# Altium schematic sheet Analog.SchDoc
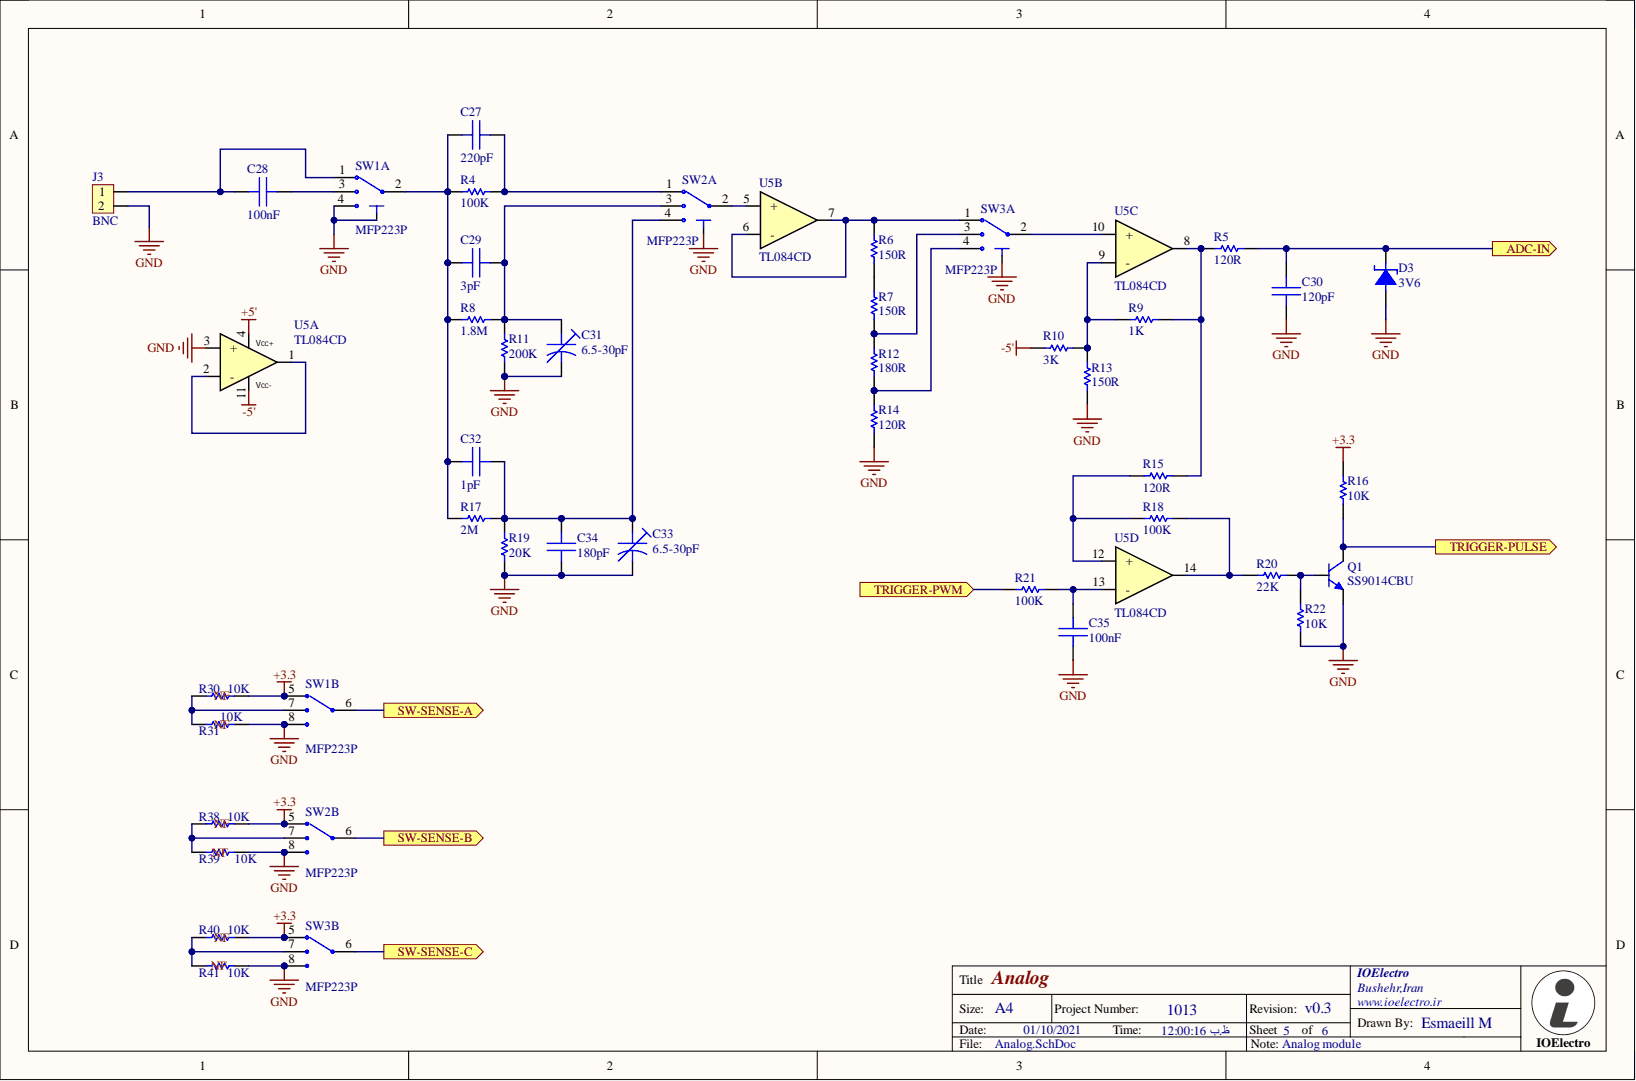
[diagram p0: Analog.SchDoc]

## Parts
C27  220pF  [C-BP-Ceramic-DIP]
C28  100nF  [C-BP-Ceramic-DIP]
C29  3pF  [C-BP-Ceramic-DIP]
C30  120pF  [C-BP-Ceramic-DIP]
C31  6.5-30pF  [C-TR-DIP_5mm]
C32  1pF  [C-BP-Ceramic-DIP]
C33  6.5-30pF  [C-TR-DIP_5mm]
C34  180pF  [C-BP-Ceramic-DIP]
C35  100nF  [C-BP-Ceramic-DIP]
D3  3V6  [SOD80]
J3  BNC  [PH-1X2-N3D]
Q1  SS9014CBU  [TO-92]
R4  100K  [R-1/4W-DIP-Blue]
R5  120R  [R-1/4W-DIP-Blue]
R6  150R  [R-1/4W-DIP-Blue]
R7  150R  [R-1/4W-DIP-Blue]
R8  1.8M  [R-1/4W-DIP-Blue]
R9  1K  [R-1/4W-DIP-Blue]
R10  3K  [R-1/4W-DIP-Blue]
R11  200K  [R-1/4W-DIP-Blue]
R12  180R  [R-1/4W-DIP-Blue]
R13  150R  [R-1/4W-DIP-Blue]
R14  120R  [R-1/4W-DIP-Blue]
R15  120R  [R-1/4W-DIP-Blue]
R16  10K  [R-0805]
R17  2M  [R-1/4W-DIP-Blue]
R18  100K  [R-1/4W-DIP-Blue]
R19  20K  [R-1/4W-DIP-Blue]
R20  22K  [R-0805]
R21  100K  [R-1/4W-DIP-Blue]
R22  10K  [R-0805]
R30  10K  [R-0805]
R31  10K  [R-0805]
R38  10K  [R-0805]
R39  10K  [R-0805]
R40  10K  [R-0805]
R41  10K  [R-0805]
SW1  MFP223P  [MFP223P]  (parts A,B)
SW2  MFP223P  [MFP223P]  (parts A,B)
SW3  MFP223P  [MFP223P]  (parts A,B)
U5  TL084CD  [SO-14]  (parts A,B,C,D)

## Ports
ADC-IN, SW-SENSE-A, SW-SENSE-B, SW-SENSE-C, TRIGGER-PULSE, TRIGGER-PWM

## Nets
+3.3: R16.2 R30.1 R38.1 R40.1 SW1.5 SW2.5 SW3.5
+5': U5.4
-5': R10.1 U5.11
GND: C30.2 C31.K C33.K C34.2 C35.2 D3.A J3.2 Q1.1 R11.1 R13.2 R14.1 R19.1 R22.1 R31.1 R39.1 R41.1 SW1.0 SW1.4 SW1.8 SW2.0 SW2.8 SW3.0 SW3.8 U5.3(+)
ADC-IN: C30.1 D3.K R5.1 ; port ADC-IN
SW-SENSE-A: SW1.6 ; port SW-SENSE-A
SW-SENSE-B: SW2.6 ; port SW-SENSE-B
SW-SENSE-C: SW3.6 ; port SW-SENSE-C
TRIGGER-PULSE: Q1.3 R16.1 ; port TRIGGER-PULSE
TRIGGER-PWM: R21.2 ; port TRIGGER-PWM
NetC27_1: C27.1 R4.2 SW2.1
NetC27_2: C27.2 C29.2 C32.2 R4.1 R8.1 R17.1 SW1.2
NetC28_1: C28.1 SW1.3
NetC28_2: C28.2 J3.1 SW1.1
NetC29_1: C29.1 C31.A R8.2 R11.2 SW2.3
NetC32_1: C32.1 C33.A C34.1 R17.2 R19.2 SW2.4
NetC35_1: C35.1 R21.1 U5.13(-)
NetQ1_2: Q1.2 R20.1 R22.2
NetR5_2: R5.2 R9.1 R15.1 U5.8
NetR6_1: R6.1 R7.2
NetR6_2: R6.2 SW3.1 U5.6(-) U5.7
NetR7_1: R7.1 R12.2 SW3.3
NetR9_2: R9.2 R10.2 R13.1 U5.9(-)
NetR12_1: R12.1 R14.2 SW3.4
NetR15_2: R15.2 R18.2 U5.12(+)
NetR18_1: R18.1 R20.2 U5.14
NetR30_2: R30.2 R31.2 SW1.7
NetR38_2: R38.2 R39.2 SW2.7
NetR40_2: R40.2 R41.2 SW3.7
NetSW2_2: SW2.2 U5.5(+)
NetSW3_2: SW3.2 U5.10(+)
NetU5_1: U5.1 U5.2(-)

## Library data of the parts
C27-C30 C32 C34 C35 = CAP: Ceramic Disk Capacitor 50V; Component Kind: Standard; Library Name: IOElectro.IntLib
C31 C33 = GKG30015: Trimmer / Variable Capacitors 6.5-30pF 100V 85 DEG; Manufacturer: Sprague Goodman; Q Factor: 300; Category: Trimmer / Variable Capacitors; Component Kind: Standard; ComponentLink1Description: http://www.mouser.com/catalog/specsheets/659305J.pdf?src-supplier=Mouser; ComponentLink1URL: http://www.mouser.com/catalog/specsheets/659305J.pdf?src-supplier=Mouser; ComponentLink2Description: https://media.digikey.com/pdf/Data%20Sheets/Sprague-Goodman%20PDFs/Ceramic%20Dielectric%20Trimmer%20Caps.pdf?src-supplier=Digi-Key; ComponentLink2URL: https://media.digikey.com/pdf/Data%20Sheets/Sprague-Goodman%20PDFs/Ceramic%20Dielectric%20Trimmer%20Caps.pdf?src-supplier=Digi-Key; ComponentLink3Description: http://www.spraguegoodman.com/pdfs/305.pdf?src-supplier=Digi-Key; ComponentLink3URL: http://www.spraguegoodman.com/pdfs/305.pdf?src-supplier=Digi-Key; Features: General Purpose; Height: 0.2inch; Height - Seated (Max): 0.197inch; Lead Free: Lead Free; Lead Pitch: 0.24inch; Length: 6mm; Library Name: IOElectro.IntLib; Manufacturer Part Number: GKG30015; Max Operating Temperature: 85°C; Min Operating Temperature: -25°C; Mount: Through Hole; Package Quantity: 500; Packaging: Bulk; Resistor Type: Ceramic; RoHS: Yes; RoHS Compliant: Yes; Series: SURFTRIM® GKG; Supplier 1: Digi-Key; Supplier Part Number 1: SG30015-ND; Termination: Snap in; Voltage Rating: 100V; Voltage Rating (DC): 100V
D3 = DIODE-Z: SMD MiniMELF; Component Kind: Standard; Library Name: IOElectro.IntLib
J3 = PH-1X2: PIN HEADER 1x2 2.56mm; Component Kind: Standard; Library Name: Schlib.SchLib
Q1 = SS9014CBU: Bipolar (BJT) Single Transistor, NPN, 45 V, 270 MHz, 450 mW, 100 mA, 200; Manufacturer: ON Semiconductor; Collector Base Voltage (VCBO): 50V; Collector Emitter Breakdown Voltage: 45V; Collector Emitter Saturation Voltage: 140mV; Collector-emitter Voltage-Max: 300mV; Current Rating: 100mA; Emitter Base Voltage (VEBO): 5V; Frequency: 270MHz; hFE Min: 60; Max Power Dissipation: 450mW; Number of Elements: 1; Case/Package: TO-92; Category: BJTs; Collector Emitter Voltage (VCEO): 45V; Component Kind: Standard; ComponentLink1Description: https://datasheet.ciiva.com/11207/ss9014-11207537.pdf?src-supplier=Avnet; ComponentLink1URL: https://datasheet.ciiva.com/11207/ss9014-11207537.pdf?src-supplier=Avnet; ComponentLink2Description: https://datasheet.ciiva.com/46415/1863528-46415612.pdf?src-supplier=Element14; ComponentLink2URL: https://datasheet.ciiva.com/46415/1863528-46415612.pdf?src-supplier=Element14; ComponentLink3Description: https://datasheet.ciiva.com/978/ss9014-978974.pdf?src-supplier=Digi-Key; ComponentLink3URL: https://datasheet.ciiva.com/978/ss9014-978974.pdf?src-supplier=Digi-Key; ComponentLink4Description: http://datasheet.octopart.com/SS9014CBU-Fairchild-datasheet-4532.pdf?src-supplier=Newark; ComponentLink4URL: http://datasheet.octopart.com/SS9014CBU-Fairchild-datasheet-4532.pdf?src-supplier=Newark; ComponentLink5Description: http://datasheet.octopart.com/SS9014CBU-ON-Semiconductor-datasheet-85608206.pdf?src-supplier=ON+Semiconductor; ComponentLink5URL: http://datasheet.octopart.com/SS9014CBU-ON-Semiconductor-datasheet-85608206.pdf?src-supplier=ON+Semiconductor; ComponentLink6Description: http://datasheet.octopart.com/SS9014CBU-ON-Semiconductor-datasheet-86698737.pdf?src-supplier=ON+Semiconductor; ComponentLink6URL: http://datasheet.octopart.com/SS9014CBU-ON-Semiconductor-datasheet-86698737.pdf?src-supplier=ON+Semiconductor; ComponentLink7Description: http://datasheet.octopart.com/SS9014CBU-ON-Semiconductor-datasheet-102168068.pdf?src-supplier=ON+Semiconductor; ComponentLink7URL: http://datasheet.octopart.com/SS9014CBU-ON-Semiconductor-datasheet-102168068.pdf?src-supplier=ON+Semiconductor; ComponentLink8Description: http://datasheet.octopart.com/SS9014CBU-ON-Semiconductor-datasheet-86693094.pdf?src-supplier=Element14; ComponentLink8URL: http://datasheet.octopart.com/SS9014CBU-ON-Semiconductor-datasheet-86693094.pdf?src-supplier=Element14; ComponentLink9Description: http://sigma.octopart.com/8329026/technical_drawing/Fairchild-Semiconductor-SS9014CBU.pdf?src-supplier=Fairchild+Semiconductor; ComponentLink9URL: http://sigma.octopart.com/8329026/technical_drawing/Fairchild-Semiconductor-SS9014CBU.pdf?src-supplier=Fairchild+Semiconductor; ComponentLink10Description: http://datasheet.octopart.com/SS9014CBU-Fairchild-Semiconductor-datasheet-67476720.pdf?src-supplier=Newark; ComponentLink10URL: http://datasheet.octopart.com/SS9014CBU-Fairchild-Semiconductor-datasheet-67476720.pdf?src-supplier=Newark; ComponentLink11Description: http://datasheet.octopart.com/SS9014CBU-Fairchild-Semiconductor-datasheet-68342036.pdf?src-supplier=Farnell; ComponentLink11URL: http://datasheet.octopart.com/SS9014CBU-Fairchild-Semiconductor-datasheet-68342036.pdf?src-supplier=Farnell; ComponentLink12Description: http://datasheet.octopart.com/SS9014CBU-Fairchild-Semiconductor-datasheet-68481733.pdf?src-supplier=Newark; ComponentLink12URL: http://datasheet.octopart.com/SS9014CBU-Fairchild-Semiconductor-datasheet-68481733.pdf?src-supplier=Newark; ComponentLink13Description: http://datasheet.octopart.com/SS9014CBU-Fairchild-Semiconductor-datasheet-68661175.pdf?src-supplier=Farnell; ComponentLink13URL: http://datasheet.octopart.com/SS9014CBU-Fairchild-Semiconductor-datasheet-68661175.pdf?src-supplier=Farnell; ComponentLink14Description: http://datasheet.octopart.com/SS9014CBU-Fairchild-Semiconductor-datasheet-78857804.pdf?src-supplier=Farnell; ComponentLink14URL: http://datasheet.octopart.com/SS9014CBU-Fairchild-Semiconductor-datasheet-78857804.pdf?src-supplier=Farnell; ComponentLink15Description: http://datasheet.octopart.com/SS9014CBU-Fairchild-Semiconductor-datasheet-78861914.pdf?src-supplier=Newark; ComponentLink15URL: http://datasheet.octopart.com/SS9014CBU-Fairchild-Semiconductor-datasheet-78861914.pdf?src-supplier=Newark; Element Configuration: Single; Gain Bandwidth Product: 270MHz; Height: 4.58mm; Lead Free: Lead Free; Length: 4.58mm; Library Name: IOElectro.IntLib; Manufacturer Part Number: SS9014CBU; Max Collector Current: 100mA; Max Frequency: 270MHz; Max Operating Temperature: 150°C; Min Operating Temperature: -55°C; Mount: Through Hole; Number of Pins: 3; Package Quantity: 1000; Packaging: Bulk; Polarity: NPN; Power Dissipation: 450mW; Radiation Hardening: No; REACH SVHC: No SVHC; RoHS Compliant: Yes; Series: -; Supplier 1: Digi-Key; Supplier Part Number 1: SS9014CBU-ND; Transition Frequency: 270MHz; Voltage Rating (DC): 45V; Weight: 0.179g; Width: 3.86mm
R4-R15 R17-R19 R21 = RES: Resistor DIP 1/4W 1%; Component Kind: Standard; Library Name: IOElectro.IntLib
R16 R20 R22 R30 R31 R38-R41 = RES: 0805; Component Kind: Standard; Library Name: IOElectro.IntLib
SW1-SW3 = MFP223P: Switch Slide ON ON ON Extended Top Slide 0.35A 30VDC 10000Cycle PC Pins Bracket Mount/Through Hole; Manufacturer: Knitter-Switch; Actuator Material: Polyoxymethylene; Max Current Rating: 200mA; Max Voltage Rating (DC): 30V; Mechanical Life: 10000Cycles; Category: Uncategorized; Component Kind: Standard; ComponentLink1Description: https://datasheet.ciiva.com/26847/getdatasheetpartid-740636-26847254.pdf?src-supplier=Verical; ComponentLink1URL: https://datasheet.ciiva.com/26847/getdatasheetpartid-740636-26847254.pdf?src-supplier=Verical; Contact Material: Copper Alloy; Contact Plating: Nickel,Silver; Depth: 6.5mm; Housing Material: Resin; Lead Free: Lead Free; Length: 16mm; Manufacturer Part Number: MFP223P; Max Operating Temperature: 85°C; Min Operating Temperature: -20°C; Mount: Through Hole,Brackets; Radiation Hardening: No; RoHS: Yes; RoHS Compliant: Yes; Supplier 1: Arrow Europe; Supplier Part Number 1: MFP223P; Termination: PC Pins
  SW1: Library Name: Schlib.SchLib
  SW2 SW3: Library Name: IOElectro.IntLib
U5 = TL084CD: IC OPAMP JFET 4MHZ 14SO; Manufacturer: STMicroelectronics; Amplifier Type: GENERAL PURPOSE; Common Mode Rejection Ratio: 70dB; Dual Supply Voltage: 15V; Input Bias Current: 200pA; Input Offset Voltage (Vos): 10mV; Max Dual Supply Voltage: 18V; Max Supply Voltage: 36V; Min Dual Supply Voltage: 3V; Min Input Voltage: -12V; Nominal Supply Current: 2.5mA; Number of Amplifiers: 4; Operating Supply Current: 1.4mA; Output Current: 40mA; Power Dissipation: 680mW; Rise Time: 50ns; Slew Rate: 16V/µs; Voltage Gain: 106.02dB; Bandwidth: 4MHz; Case/Package: SOIC; Category: Amplifiers - Audio; Component Kind: Standard; ComponentLink1Description: https://datasheet.ciiva.com/15129/getdatasheetpartid-40720-15129705.pdf?src-supplier=Verical; ComponentLink1URL: https://datasheet.ciiva.com/15129/getdatasheetpartid-40720-15129705.pdf?src-supplier=Verical; ComponentLink2Description: https://datasheet.ciiva.com/29306/155629-da-01-en-op-verst-tl084cd-stm-29306876.pdf?src-supplier=Conrad; ComponentLink2URL: https://datasheet.ciiva.com/29306/155629-da-01-en-op-verst-tl084cd-stm-29306876.pdf?src-supplier=Conrad; ComponentLink3Description: https://datasheet.ciiva.com/1796/cd00000493-1796695.pdf?src-supplier=Digi-Key; ComponentLink3URL: https://datasheet.ciiva.com/1796/cd00000493-1796695.pdf?src-supplier=Digi-Key; ComponentLink4Description: https://datasheet.ciiva.com/16385/cd00000493-16385768.pdf?src-supplier=Future+Electronics; ComponentLink4URL: https://datasheet.ciiva.com/16385/cd00000493-16385768.pdf?src-supplier=Future+Electronics; Gain Bandwidth Product: 4MHz; Height: 1.65mm; Input Offset Voltage-Max: 10mV; Lead Free: Lead Free; Length: 8.75mm; Library Name: IOElectro.IntLib; Manufacturer Part Number: TL084CD; Max Input Voltage: 15V; Max Operating Temperature: 70°C; Min Operating Temperature: 0°C; Mount: Surface Mount; Number of Channels: 4; Number of Circuits: 4; Number of Elements: 4; Number of Pins: 14; Operating Supply Voltage: 18V; Output Current per Channel: 40mA; Package Quantity: 50; Packaging: Rail/Tube; Pricing 1: 1=0/56000, 10=0/47700, 25=0/44520, 100=0/35620, 250=0/33072, 500=0/27984, 1000=0/21624, 2500=0/21000 (USD); Radiation Hardening: No; REACH SVHC: No SVHC; RoHS: Yes; RoHS Compliant: Yes; Slew Rate-Nom: 16V/µs; Stock 1: 3951; Supplier 1: Digi-Key; Supplier Part Number 1: 497-TL084CD-ND; Supply Current: 2.5mA; Technology: BIFET; Unity Gain Bandwidth Product: 4MHz; Weight: 0.01lb; Width: 4mm
